# Altium schematic sheet SchematicOct11.SchDoc(SchematicOct11)
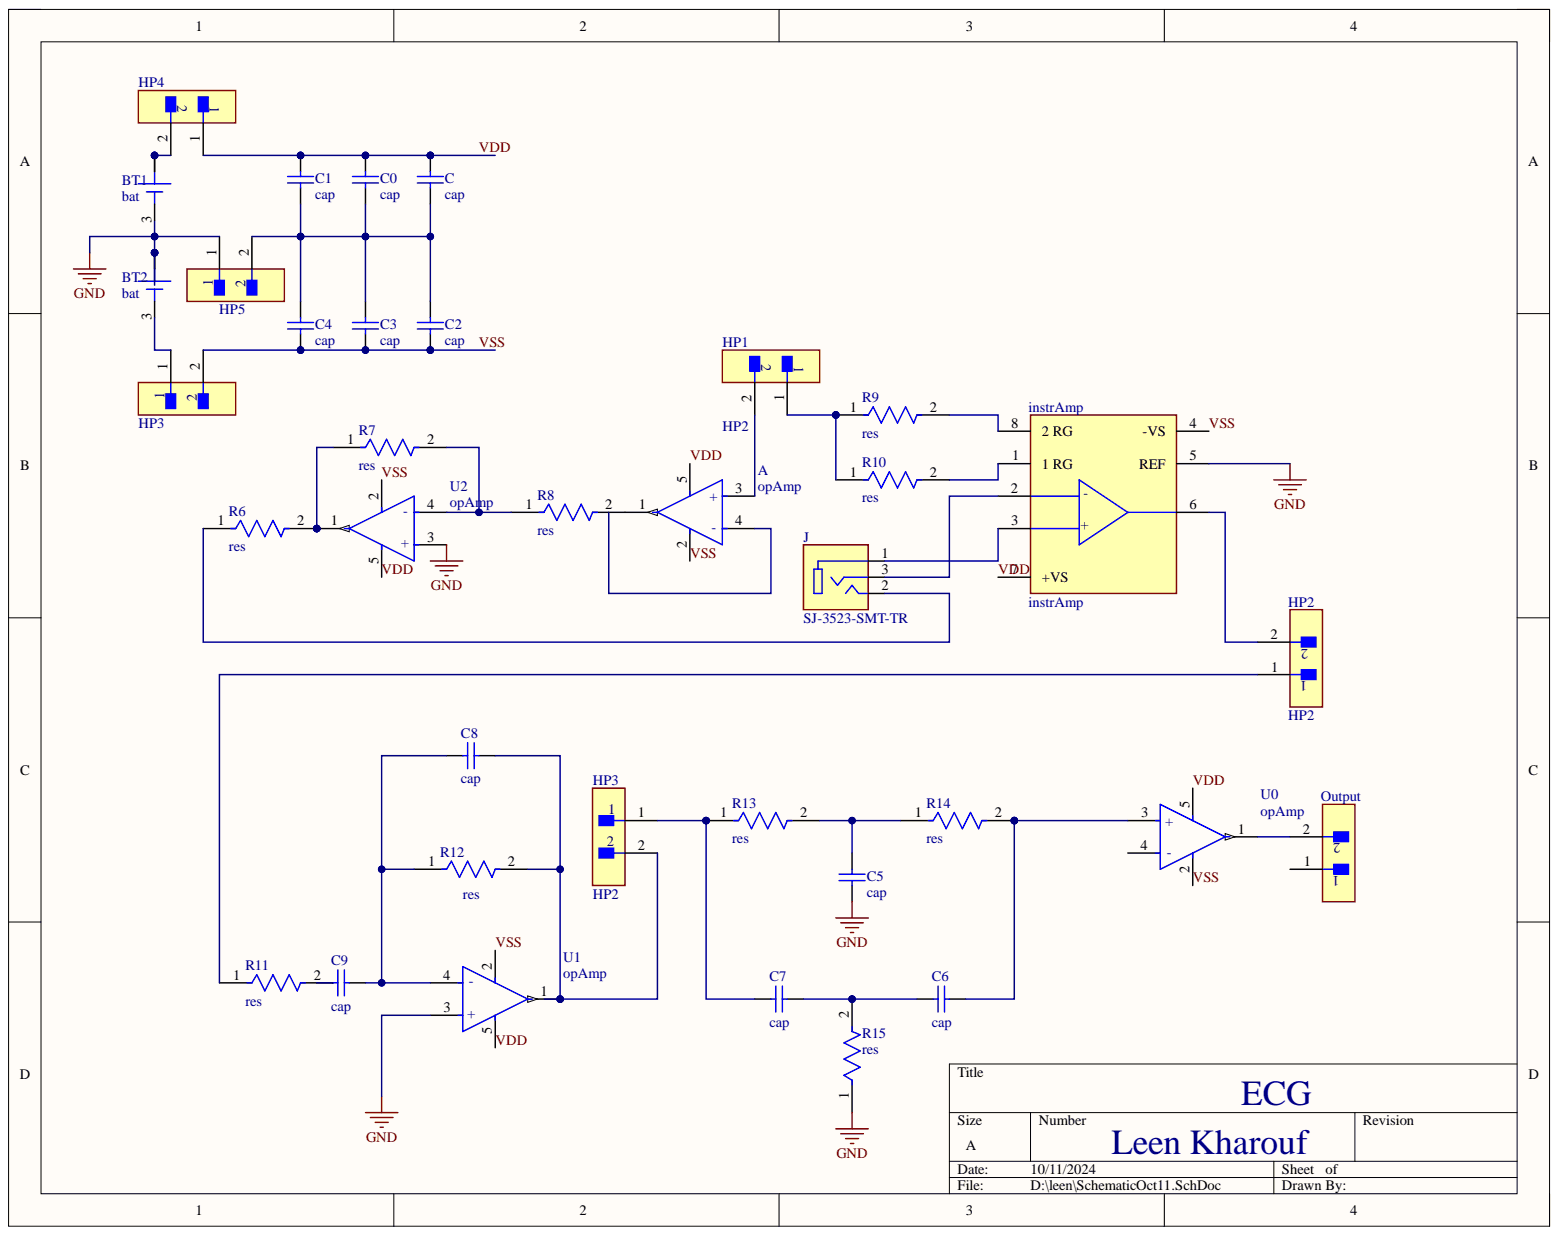
[diagram p0: SchematicOct11.SchDoc]

## Parts
A  opAmp  [FP-DCK0005A-MFG]
BT1  bat  [BAT_BK-913-TR]
BT2  bat  [BAT_BK-913-TR]
C  cap  [CAPC2013X145X50ML20T25]
C0  cap  [CAPC2013X145X50ML20T25]
C1  cap  [CAPC2013X145X50ML20T25]
C2  cap  [CAPC2013X145X50ML20T25]
C3  cap  [CAPC2013X145X50ML20T25]
C4  cap  [CAPC2013X145X50ML20T25]
C5  cap  [CAPC2013X145X50ML20T25]
C6  cap  [CAPC2013X145X50ML20T25]
C7  cap  [CAPC2013X145X50ML20T25]
C8  cap  [CAPC2013X145X50ML20T25]
C9  cap  [CAPC2013X145X50ML20T25]
HP1  HP2  [FP-TSW-102-07-L-S-MFG]
HP2  HP2  [FP-TSW-102-07-L-S-MFG]
HP3  (no value)  [FP-TSW-102-07-L-S-MFG]
HP3  HP2  [FP-TSW-102-07-L-S-MFG]
HP4  (no value)  [FP-TSW-102-07-L-S-MFG]
HP5  (no value)  [FP-TSW-102-07-L-S-MFG]
instrAmp  instrAmp  [FP-R-8-IPC_B]
J  SJ-3523-SMT-TR  [CUI_SJ-3523-SMT-TR]
Output  (no value)  [FP-TSW-102-07-L-S-MFG]
R6  res  [FP-CRCW0805-e3-IPC_C]
R7  res  [FP-CRCW0805-e3-IPC_C]
R8  res  [FP-CRCW0805-e3-IPC_C]
R9  res  [FP-CRCW0805-e3-IPC_C]
R10  res  [FP-CRCW0805-e3-IPC_C]
R11  res  [FP-CRCW0805-e3-IPC_C]
R12  res  [FP-CRCW0805-e3-IPC_C]
R13  res  [FP-CRCW0805-e3-IPC_C]
R14  res  [FP-CRCW0805-e3-IPC_C]
R15  res  [FP-CRCW0805-e3-IPC_C]
U0  opAmp  [FP-DCK0005A-MFG]
U1  opAmp  [FP-DCK0005A-MFG]
U2  opAmp  [FP-DCK0005A-MFG]

## Nets
GND: BT1.3 BT2.1 BT2.2 C5.1 HP5.1 instrAmp.5(REF) R15.1 U1.3(+) U2.3(+)
VDD: A.5 C.2 C0.2 C1.2 HP4.1 instrAmp.7(+VS) U0.5 U1.5 U2.5
VSS: A.2 C2.1 C3.1 C4.1 HP3.2 instrAmp.4(-VS) U0.2 U1.2 U2.2
NetA_1: A.1 R8.2
NetA_3: A.3(+) HP1.2
NetBT1_1: BT1.1 BT1.2 HP4.2
NetBT2_3: BT2.3 HP3.1
NetC0_1: C.1 C0.1 C1.1 C2.2 C3.2 C4.2 HP5.2
NetC5_2: C5.2 R13.2 R14.1
NetC6_1: C6.1 C7.2 R15.2
NetC6_2: C6.2 R14.2 U0.3(+)
NetC7_1: C7.1 HP3.1 R13.1
NetC8_1: C8.1 C9.2 R12.1 U1.4(-)
NetC8_2: C8.2 HP3.2 R12.2 U1.1
NetC9_1: C9.1 R11.2
NetHP1_1: HP1.1 R9.1 R10.1
NetHP2_1: HP2.1 R11.1
NetHP2_2: HP2.2 instrAmp.6
NetinstrAmp_1: instrAmp.1(1_RG) R10.2
NetinstrAmp_2: instrAmp.2 J.3
NetinstrAmp_3: instrAmp.3 J.1
NetinstrAmp_8: instrAmp.8(2_RG) R9.2
NetJ_2: J.2 R6.1
NetOutput_2: Output.2 U0.1
NetR6_2: R6.2 R7.1 U2.1
NetR7_2: R7.2 R8.1 U2.4(-)

Not connected: A 4(-); Output 1; U0 4(-)

## Library data of the parts
A U0-U2 = CMP-04895-000072-1: IC CMOS 1 CIRCUIT SC70-5; Bandwidth: 6kHz; Input Bias Current: 1pA; Input Offset Voltage (Vos): 100uV; Number of Amplifiers: 1; Operating Supply Current: 350nA; Output Current per Channel: 12mA; Slew Rate: 2.5V/µs; Case/Package: SC; Component Kind: Standard; Gain Bandwidth Product: 6kHz; Lead Free: Lead Free; Library Name: Altium Content Vault; Max Operating Temperature: 125°C; Min Operating Temperature: -40°C; Mount: Surface Mount; Number of Channels: 1; Number of Circuits: 1; Number of Pins: 5; Packaging: Cut Tape; REACH SVHC: No SVHC
BT1 BT2 = BK-913-TR: Battery Retainer Coin, 20.0mm 1 Cell PC Pin; MF: MPD (Memory; Component Kind: Standard; Digi-Key_Part_Number: BK-913-CT-ND; Library Name: Schlib2024.SchLib; MP: BK-913-TR; Package: MSOP-12 MPD; SnapEDA_Link: https://www.snapeda.com/parts/BK-913-TR/MPD%20(Memory%20Protection%20Devices)/view-part/576523/?ref=snap
C C0-C9 = CMP-1036-04819-2: Manufacturer: Kemet; Part Number: C0805C225K9PACTU; Component Kind: Standard; Library Name: Altium Content Vault
HP1-HP3 HP3-HP5 Output = CMP-02766-001428-1: CONN HEADER VERT 2POS 2.54MM; Connector Type: Male Pin; Current Rating: 7.6A; Gender: Male; Max Voltage Rating (AC): 550V; Number of Contacts: 2; Color: Black; Component Kind: Standard; Contact Material: Bronze; Contact Mating Length: 0.23inch; Contact Plating: Gold; Contact Type: Male Pin; Depth: 2.54mm; Housing Color: Black; Housing Material: Polyester; Lead Free: Lead Free; Length: 5.08mm; Library Name: Altium Content Vault; Max Current Rating: 7.6A; Max Operating Temperature: 125°C; Min Operating Temperature: -55°C; Mount: Through Hole; Number of Positions: 2; Number of Rows: 1; Orientation: Straight; Package Quantity: 1; Packaging: Bulk; Pitch: 2.54mm; Radiation Hardening: No; REACH SVHC: No SVHC; RoHS Compliant: Yes; Series: TSW; Termination: Solder; Voltage Rating: 550V; Width: 2.54mm
instrAmp = CMP-09116-000354-1: IC INST AMP 1 CIRCUIT 8SOIC; -3db Bandwidth: 1MHz; Bandwidth: 800kHz; Common Mode Rejection Ratio: 93dB; Dual Supply Voltage: 15V; Input Bias Current: 500pA; Input Offset Voltage (Vos): 30mV; Input Resistance: 10GR; Max Dual Supply Voltage: 18V; Max Power Dissipation: 650mW; Min Dual Supply Voltage: 2.3V; Min Supply Voltage: 4.6V; Nominal Supply Current: 900uA; Number of Channels: 1; Operating Supply Current: 1.3mA; Output Current per Channel: 18mA; Power Supply Rejection Ratio (PSRR): 140dB; Slew Rate: 1.2V/µs; Case/Package: SOIC; Component Kind: Standard; Contact Plating: Tin; Lead Free: Contains Lead; Library Name: Altium Content Vault; Max Operating Temperature: 125°C; Min Operating Temperature: -55°C; Mount: Surface Mount; Number of Circuits: 1; Number of Elements: 1; Number of Pins: 8; Operating Supply Voltage: 18V; Package Quantity: 1; Packaging: Tape and Reel; Power Dissipation: 650mW; Radiation Hardening: No; RoHS Compliant: Yes; Series: -; Supply Current: 900uA
J = SJ-3523-SMT-TR: <b>Audio Jack Connector</b> 3.5 mm Low Profile Surface Mount Stereo Jack, 3 Pin Right-Angle; Availability: Unavailable; Component Kind: Standard; Library Name: Schlib2024.SchLib; MP: SJ-3523-SMT-TR; Package: None; Price: None
R6-R11 R13-R15 = CMP-2001-04979-2: RES Thick Film, 16kΩ, 1%, 0.125W, 100ppm/°C, 0805; Max Power Dissipation: 125mW; Resistance: 1kR; Voltage Rating: 150V; Case Code (Imperial): 0805; Case Code (Metric): 2012; Case/Package: 0805; Component Kind: Standard; Composition: Thick Film; Contact Plating: Tin; Depth: 1.25mm; Features: Automotive AEC-Q200; Height: 0.45mm; Lead Free: Lead Free; Length: 2mm; Library Name: Altium Content Vault; Max Operating Temperature: 155°C; Military Standard: Not; Min Operating Temperature: -55°C; Mount: Surface Mount; Number of Pins: 2; Number of Terminations: 2; Package Quantity: 10; Packaging: Tape and Reel; Power Rating: 125mW; Radiation Hardening: No; Temperature Coefficient: 100ppm/°C; Termination: SMD/SMT; Tolerance: 1%; Voltage Rating (DC): 150V; Weight: 0.000194oz; Width: 1.25mm
R12 = CMP-2001-04979-2: RES Thick Film, 16kΩ, 1%, 0.125W, 100ppm/°C, 0805; Max Power Dissipation: 125mW; Resistance: 100kR; Voltage Rating: 150V; Case Code (Imperial): 0805; Case Code (Metric): 2012; Case/Package: 0805; Component Kind: Standard; Composition: Thick Film; Contact Plating: Tin; Depth: 1.25mm; Features: Automotive AEC-Q200; Height: 0.45mm; Lead Free: Lead Free; Length: 2mm; Library Name: Altium Content Vault; Max Operating Temperature: 155°C; Military Standard: Not; Min Operating Temperature: -55°C; Mount: Surface Mount; Number of Pins: 2; Number of Terminations: 2; Package Quantity: 10; Packaging: Tape and Reel; Power Rating: 125mW; Radiation Hardening: No; Temperature Coefficient: 100ppm/°C; Termination: SMD/SMT; Tolerance: 1%; Voltage Rating (DC): 150V; Weight: 0.000194oz; Width: 1.25mm
